# Altium schematic sheet P1.SchDoc
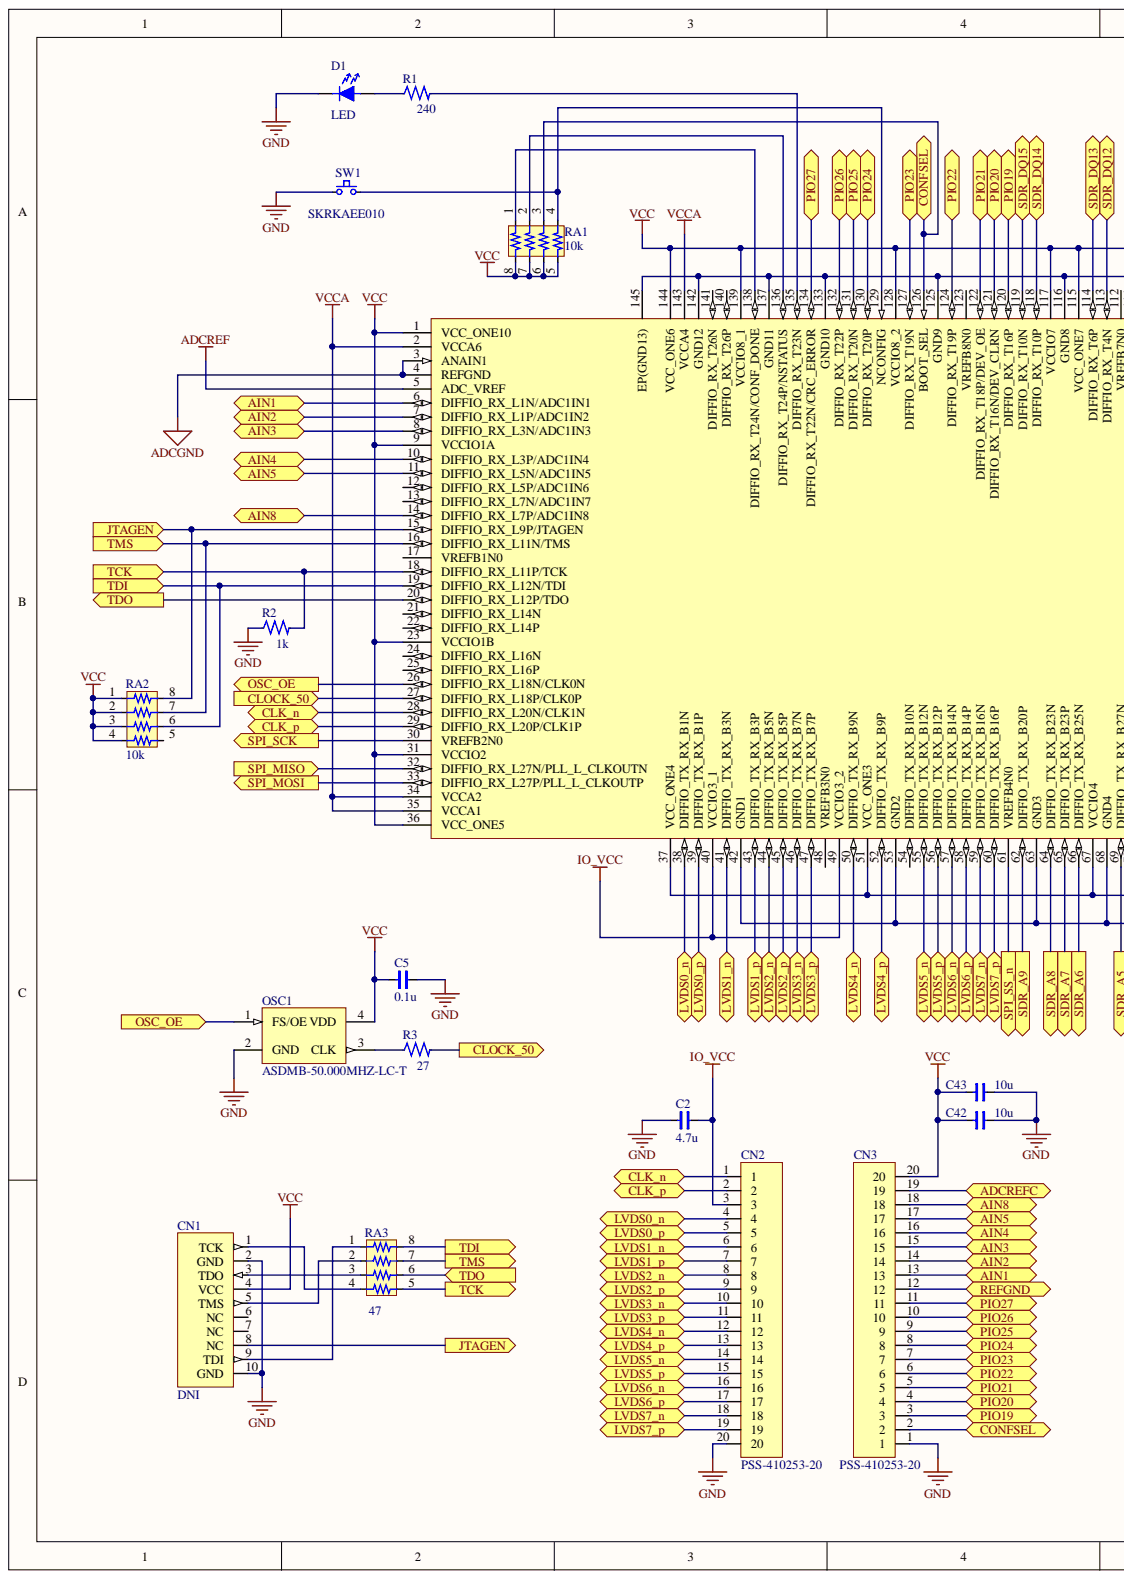
[diagram p0: P1.SchDoc, left]
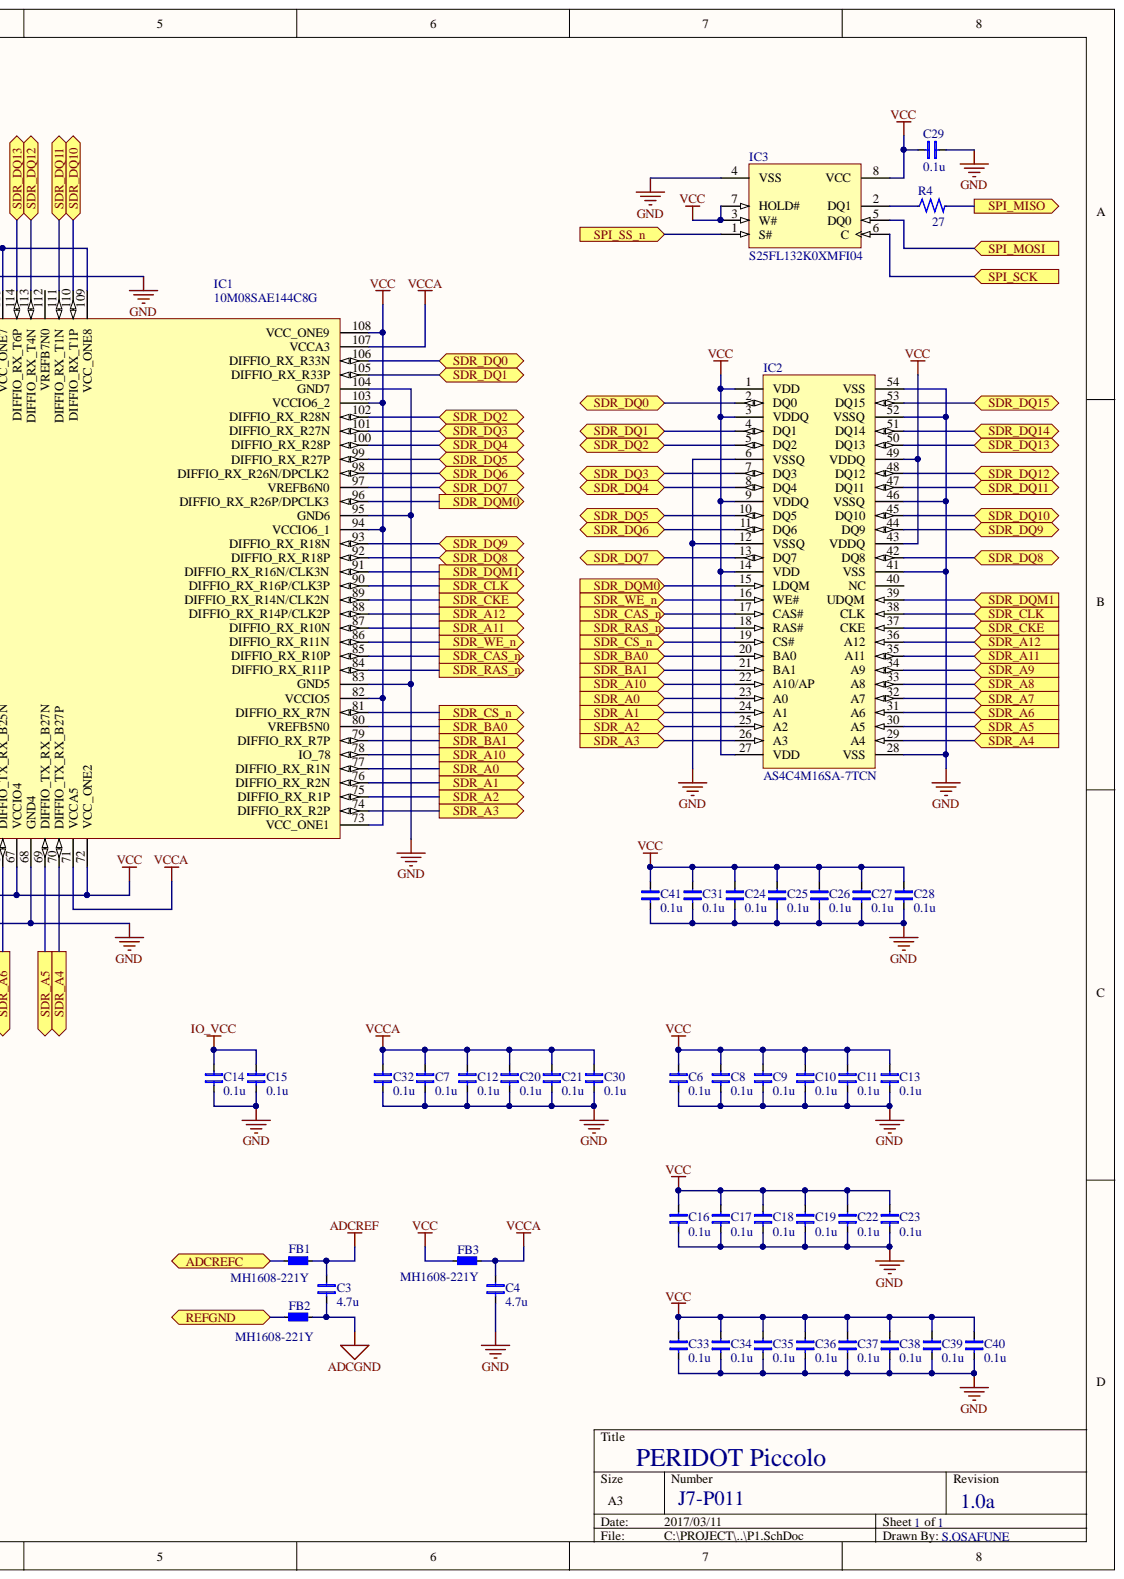
[diagram p1: P1.SchDoc, right]

## Parts
C2  4.7u  [C1608]
C3  4.7u  [C1608]
C4  4.7u  [C1608]
C5  0.1u  [C1608]
C6  0.1u  [C1608]
C7  0.1u  [C1608]
C8  0.1u  [C1608]
C9  0.1u  [C1608]
C10  0.1u  [C1608]
C11  0.1u  [C1608]
C12  0.1u  [C1608]
C13  0.1u  [C1608]
C14  0.1u  [C1608]
C15  0.1u  [C1608]
C16  0.1u  [C1608]
C17  0.1u  [C1608]
C18  0.1u  [C1608]
C19  0.1u  [C1608]
C20  0.1u  [C1608]
C21  0.1u  [C1608]
C22  0.1u  [C1608]
C23  0.1u  [C1608]
C24  0.1u  [C1608]
C25  0.1u  [C1608]
C26  0.1u  [C1608]
C27  0.1u  [C1608]
C28  0.1u  [C1608]
C29  0.1u  [C1608]
C30  0.1u  [C1608]
C31  0.1u  [C1608]
C32  0.1u  [C1608]
C33  0.1u  [C1608]
C34  0.1u  [C1608]
C35  0.1u  [C1608]
C36  0.1u  [C1608]
C37  0.1u  [C1608]
C38  0.1u  [C1608]
C39  0.1u  [C1608]
C40  0.1u  [C1608]
C41  0.1u  [C1608]
C42  10u  [C2012]
C43  10u  [C2012]
CN1  DNI  [PSS-420156-05]
CN2  PSS-410253-20  [PSS-410253-20]
CN3  PSS-410253-20  [PSS-410253-20]
D1  LED  [LED1608]
FB1  MH1608-221Y  [L1608]
FB2  MH1608-221Y  [L1608]
FB3  MH1608-221Y  [L1608]
IC1  10M08SAE144C8G  [10M08SAE144C8GES]
IC2  AS4C4M16SA-7TCN  [AS4C16M16S]
IC3  S25FL132K0XMFI04  [M25P16-VMN6P]
OSC1  ASDMB-50.000MHZ-LC-T  [SI50xC]
R1  240  [R1608]
R2  1k  [R1608]
R3  27  [R1608]
R4  27  [R1608]
RA1  10k  [RA1608X4]
RA2  10k  [RA1608X4]
RA3  47  [RA1608X4]
SW1  SKRKAEE010  [SKRKAEE010]

## Ports
ADCREFC, AIN1, AIN2, AIN3, AIN4, AIN5, AIN8, CLK_N, CLK_P, CLOCK_50, CONFSEL, JTAGEN, LVDS0_N, LVDS0_P, LVDS1_N, LVDS1_P, LVDS2_N, LVDS2_P, LVDS3_N, LVDS3_P, LVDS4_N, LVDS4_P, LVDS5_N, LVDS5_P, LVDS6_N, LVDS6_P, LVDS7_N, LVDS7_P, OSC_OE, PIO19, PIO20, PIO21, PIO22, PIO23, PIO24, PIO25, PIO26, PIO27, REFGND, SDR_A0, SDR_A1, SDR_A2, SDR_A3, SDR_A4, SDR_A5, SDR_A6, SDR_A7, SDR_A8, SDR_A9, SDR_A10, SDR_A11, SDR_A12, SDR_BA0, SDR_BA1, SDR_CAS_N, SDR_CKE, SDR_CLK, SDR_CS_N, SDR_DQ0, SDR_DQ1, SDR_DQ2, SDR_DQ3, SDR_DQ4, SDR_DQ5, SDR_DQ6, SDR_DQ7, SDR_DQ8, SDR_DQ9, SDR_DQ10, SDR_DQ11, SDR_DQ12, SDR_DQ13, SDR_DQ14, SDR_DQ15, SDR_DQM0, SDR_DQM1, SDR_RAS_N, SDR_WE_N, SPI_MISO, SPI_MOSI, SPI_SCK, SPI_SS_N, TCK, TDI, TDO, TMS

## Nets
ADCGND: C3.1 FB2.2 IC1.3(ANAIN1) IC1.4(REFGND)
ADCREF: C3.2 FB1.2 IC1.5(ADC_VREF)
CLK_N: CN2.1 IC1.28(DIFFIO_RX_L20N/CLK1N) ; port CLK_N
CLK_P: CN2.2 IC1.29(DIFFIO_RX_L20P/CLK1P) ; port CLK_P
GND: C2.1 C4.1 C5.2 C6.1 C7.1 C8.1 C9.1 C10.1 C11.1 C12.1 C13.1 C14.1 C15.1 C16.1 C17.1 C18.1 C19.1 C20.1 C21.1 C22.1 C23.1 C24.1 C25.1 C26.1 C27.1 C28.1 C29.2 C30.1 C31.1 C32.1 C33.1 C34.1 C35.1 C36.1 C37.1 C38.1 C39.1 C40.1 C41.1 C42.2 C43.2 CN1.2(GND) CN1.10(GND) CN2.20 CN3.1 D1.K IC1.42(GND1) IC1.53(GND2) IC1.63(GND3) IC1.68(GND4) IC1.83(GND5) IC1.95(GND6) IC1.104(GND7) IC1.116(GND8) IC1.125(GND9) IC1.133(GND10) IC1.137(GND11) IC1.142(GND12) IC1.145(EP(GND13)) IC2.6(VSSQ) IC2.12(VSSQ) IC2.28(VSS) IC2.41(VSS) IC2.46(VSSQ) IC2.52(VSSQ) IC2.54(VSS) IC3.4(VSS) OSC1.2(GND) R2.1 SW1.1
IO_VCC: C2.2 C14.2 C15.2 CN2.3 IC1.40(VCCIO3_1) IC1.49(VCCIO3_2)
LVDS0_N: CN2.4 IC1.38(DIFFIO_TX_RX_B1N) ; port LVDS0_N
LVDS0_P: CN2.5 IC1.39(DIFFIO_TX_RX_B1P) ; port LVDS0_P
LVDS1_N: CN2.6 IC1.41(DIFFIO_TX_RX_B3N) ; port LVDS1_N
LVDS1_P: CN2.7 IC1.43(DIFFIO_TX_RX_B3P) ; port LVDS1_P
LVDS2_N: CN2.8 IC1.44(DIFFIO_TX_RX_B5N) ; port LVDS2_N
LVDS2_P: CN2.9 IC1.45(DIFFIO_TX_RX_B5P) ; port LVDS2_P
LVDS3_N: CN2.10 IC1.46(DIFFIO_TX_RX_B7N) ; port LVDS3_N
LVDS3_P: CN2.11 IC1.47(DIFFIO_TX_RX_B7P) ; port LVDS3_P
LVDS4_N: CN2.12 IC1.50(DIFFIO_TX_RX_B9N) ; port LVDS4_N
LVDS4_P: CN2.13 IC1.52(DIFFIO_TX_RX_B9P) ; port LVDS4_P
LVDS5_N: CN2.14 IC1.55(DIFFIO_TX_RX_B12N) ; port LVDS5_N
LVDS5_P: CN2.15 IC1.56(DIFFIO_TX_RX_B12P) ; port LVDS5_P
LVDS6_N: CN2.16 IC1.57(DIFFIO_TX_RX_B14N) ; port LVDS6_N
LVDS6_P: CN2.17 IC1.58(DIFFIO_TX_RX_B14P) ; port LVDS6_P
LVDS7_N: CN2.18 IC1.59(DIFFIO_TX_RX_B16N) ; port LVDS7_N
LVDS7_P: CN2.19 IC1.60(DIFFIO_TX_RX_B16P) ; port LVDS7_P
SDR_CAS_N: IC1.85(DIFFIO_RX_R10P) IC2.17(CAS#) ; port SDR_CAS_N
SDR_CS_N: IC1.81(DIFFIO_RX_R7N) IC2.19(CS#) ; port SDR_CS_N
SDR_RAS_N: IC1.84(DIFFIO_RX_R11P) IC2.18(RAS#) ; port SDR_RAS_N
SDR_WE_N: IC1.86(DIFFIO_RX_R11N) IC2.16(WE#) ; port SDR_WE_N
SPI_SS_N: IC1.61(VREFB4N0) IC3.1(S#) ; port SPI_SS_N
VCC: C5.1 C6.2 C8.2 C9.2 C10.2 C11.2 C13.2 C16.2 C17.2 C18.2 C19.2 C22.2 C23.2 C24.2 C25.2 C26.2 C27.2 C28.2 C29.1 C31.2 C33.2 C34.2 C35.2 C36.2 C37.2 C38.2 C39.2 C40.2 C41.2 C42.1 C43.1 CN1.4(VCC) CN3.20 FB3.1 IC1.1(VCC_ONE10) IC1.9(VCCIO1A) IC1.23(VCCIO1B) IC1.31(VCCIO2) IC1.36(VCC_ONE5) IC1.37(VCC_ONE4) IC1.51(VCC_ONE3) IC1.67(VCCIO4) IC1.72(VCC_ONE2) IC1.73(VCC_ONE1) IC1.82(VCCIO5) IC1.94(VCCIO6_1) IC1.103(VCCIO6_2) IC1.108(VCC_ONE9) IC1.109(VCC_ONE8) IC1.115(VCC_ONE7) IC1.117(VCCIO7) IC1.128(VCCIO8_2) IC1.139(VCCIO8_1) IC1.144(VCC_ONE6) IC2.1(VDD) IC2.3(VDDQ) IC2.9(VDDQ) IC2.14(VDD) IC2.27(VDD) IC2.43(VDDQ) IC2.49(VDDQ) IC3.3(W#) IC3.7(HOLD#) IC3.8(VCC) OSC1.4(VDD) RA1.5 RA1.6 RA1.7 RA1.8 RA2.1 RA2.2 RA2.3 RA2.4
VCCA: C4.2 C7.2 C12.2 C20.2 C21.2 C30.2 C32.2 FB3.2 IC1.2(VCCA6) IC1.34(VCCA2) IC1.35(VCCA1) IC1.71(VCCA5) IC1.107(VCCA3) IC1.143(VCCA4)
ADCREFC: CN3.19 FB1.1 ; port ADCREFC
AIN1: CN3.13 IC1.6(DIFFIO_RX_L1N/ADC1IN1) ; port AIN1
AIN2: CN3.14 IC1.7(DIFFIO_RX_L1P/ADC1IN2) ; port AIN2
AIN3: CN3.15 IC1.8(DIFFIO_RX_L3N/ADC1IN3) ; port AIN3
AIN4: CN3.16 IC1.10(DIFFIO_RX_L3P/ADC1IN4) ; port AIN4
AIN5: CN3.17 IC1.11(DIFFIO_RX_L5N/ADC1IN5) ; port AIN5
AIN8: CN3.18 IC1.14(DIFFIO_RX_L7P/ADC1IN8) ; port AIN8
CLOCK_50: IC1.27(DIFFIO_RX_L18P/CLK0P) R3.2 ; port CLOCK_50
CONFSEL: CN3.2 IC1.126(BOOT_SEL) RA1.3 ; port CONFSEL
JTAGEN: CN1.8(NC) IC1.15(DIFFIO_RX_L9P/JTAGEN) RA2.8 ; port JTAGEN
OSC_OE: IC1.26(DIFFIO_RX_L18N/CLK0N) OSC1.1(FS/OE) ; port OSC_OE
PIO19: CN3.3 IC1.120(DIFFIO_RX_T16P) ; port PIO19
PIO20: CN3.4 IC1.121(DIFFIO_RX_T16N/DEV_CLRN) ; port PIO20
PIO21: CN3.5 IC1.122(DIFFIO_RX_T18P/DEV_OE) ; port PIO21
PIO22: CN3.6 IC1.124(DIFFIO_RX_T19P) ; port PIO22
PIO23: CN3.7 IC1.127(DIFFIO_RX_T19N) ; port PIO23
PIO24: CN3.8 IC1.130(DIFFIO_RX_T20P) ; port PIO24
PIO25: CN3.9 IC1.131(DIFFIO_RX_T20N) ; port PIO25
PIO26: CN3.10 IC1.132(DIFFIO_RX_T22P) ; port PIO26
PIO27: CN3.11 IC1.134(DIFFIO_RX_T22N/CRC_ERROR) ; port PIO27
REFGND: CN3.12 FB2.1 ; port REFGND
SDR_A0: IC1.77(DIFFIO_RX_R1N) IC2.23(A0) ; port SDR_A0
SDR_A1: IC1.76(DIFFIO_RX_R2N) IC2.24(A1) ; port SDR_A1
SDR_A2: IC1.75(DIFFIO_RX_R1P) IC2.25(A2) ; port SDR_A2
SDR_A3: IC1.74(DIFFIO_RX_R2P) IC2.26(A3) ; port SDR_A3
SDR_A4: IC1.70(DIFFIO_TX_RX_B27P) IC2.29(A4) ; port SDR_A4
SDR_A5: IC1.69(DIFFIO_TX_RX_B27N) IC2.30(A5) ; port SDR_A5
SDR_A6: IC1.66(DIFFIO_TX_RX_B25N) IC2.31(A6) ; port SDR_A6
SDR_A7: IC1.65(DIFFIO_TX_RX_B23P) IC2.32(A7) ; port SDR_A7
SDR_A8: IC1.64(DIFFIO_TX_RX_B23N) IC2.33(A8) ; port SDR_A8
SDR_A9: IC1.62(DIFFIO_TX_RX_B20P) IC2.34(A9) ; port SDR_A9
SDR_A10: IC1.78(IO_78) IC2.22(A10/AP) ; port SDR_A10
SDR_A11: IC1.87(DIFFIO_RX_R10N) IC2.35(A11) ; port SDR_A11
SDR_A12: IC1.88(DIFFIO_RX_R14P/CLK2P) IC2.36(A12) ; port SDR_A12
SDR_BA0: IC1.80(VREFB5N0) IC2.20(BA0) ; port SDR_BA0
SDR_BA1: IC1.79(DIFFIO_RX_R7P) IC2.21(BA1) ; port SDR_BA1
SDR_CKE: IC1.89(DIFFIO_RX_R14N/CLK2N) IC2.37(CKE) ; port SDR_CKE
SDR_CLK: IC1.90(DIFFIO_RX_R16P/CLK3P) IC2.38(CLK) ; port SDR_CLK
SDR_DQ0: IC1.106(DIFFIO_RX_R33N) IC2.2(DQ0) ; port SDR_DQ0
SDR_DQ1: IC1.105(DIFFIO_RX_R33P) IC2.4(DQ1) ; port SDR_DQ1
SDR_DQ2: IC1.102(DIFFIO_RX_R28N) IC2.5(DQ2) ; port SDR_DQ2
SDR_DQ3: IC1.101(DIFFIO_RX_R27N) IC2.7(DQ3) ; port SDR_DQ3
SDR_DQ4: IC1.100(DIFFIO_RX_R28P) IC2.8(DQ4) ; port SDR_DQ4
SDR_DQ5: IC1.99(DIFFIO_RX_R27P) IC2.10(DQ5) ; port SDR_DQ5
SDR_DQ6: IC1.98(DIFFIO_RX_R26N/DPCLK2) IC2.11(DQ6) ; port SDR_DQ6
SDR_DQ7: IC1.97(VREFB6N0) IC2.13(DQ7) ; port SDR_DQ7
SDR_DQ8: IC1.92(DIFFIO_RX_R18P) IC2.42(DQ8) ; port SDR_DQ8
SDR_DQ9: IC1.93(DIFFIO_RX_R18N) IC2.44(DQ9) ; port SDR_DQ9
SDR_DQ10: IC1.110(DIFFIO_RX_T1P) IC2.45(DQ10) ; port SDR_DQ10
SDR_DQ11: IC1.111(DIFFIO_RX_T1N) IC2.47(DQ11) ; port SDR_DQ11
SDR_DQ12: IC1.113(DIFFIO_RX_T4N) IC2.48(DQ12) ; port SDR_DQ12
SDR_DQ13: IC1.114(DIFFIO_RX_T6P) IC2.50(DQ13) ; port SDR_DQ13
SDR_DQ14: IC1.118(DIFFIO_RX_T10P) IC2.51(DQ14) ; port SDR_DQ14
SDR_DQ15: IC1.119(DIFFIO_RX_T10N) IC2.53(DQ15) ; port SDR_DQ15
SDR_DQM0: IC1.96(DIFFIO_RX_R26P/DPCLK3) IC2.15(LDQM) ; port SDR_DQM0
SDR_DQM1: IC1.91(DIFFIO_RX_R16N/CLK3N) IC2.39(UDQM) ; port SDR_DQM1
SPI_MISO: IC1.32(DIFFIO_RX_L27N/PLL_L_CLKOUTN) R4.2 ; port SPI_MISO
SPI_MOSI: IC1.33(DIFFIO_RX_L27P/PLL_L_CLKOUTP) IC3.5(DQ0) ; port SPI_MOSI
SPI_SCK: IC1.30(VREFB2N0) IC3.6(C) ; port SPI_SCK
TCK: IC1.18(DIFFIO_RX_L11P/TCK) R2.2 RA3.5 ; port TCK
TDI: IC1.19(DIFFIO_RX_L12N/TDI) RA2.6 RA3.8 ; port TDI
TDO: IC1.20(DIFFIO_RX_L12P/TDO) RA3.6 ; port TDO
TMS: IC1.16(DIFFIO_RX_L11N/TMS) RA2.7 RA3.7 ; port TMS
NetCN1_1: CN1.1(TCK) RA3.4
NetCN1_3: CN1.3(TDO) RA3.3
NetCN1_5: CN1.5(TMS) RA3.2
NetCN1_9: CN1.9(TDI) RA3.1
NetD1_A: D1.A R1.1
NetIC1_129: IC1.129(NCONFIG) RA1.4 SW1.2
NetIC1_135: IC1.135(DIFFIO_RX_T23N) R1.2
NetIC1_136: IC1.136(DIFFIO_RX_T24P/NSTATUS) RA1.2
NetIC1_138: IC1.138(DIFFIO_RX_T24N/CONF_DONE) RA1.1
NetIC3_2: IC3.2(DQ1) R4.1
NetOSC1_3: OSC1.3(CLK) R3.1

Not connected: CN1 6(NC) 7(NC); IC1 12(DIFFIO_RX_L5P/ADC1IN6) 13(DIFFIO_RX_L7N/ADC1IN7) 17(VREFB1N0) 21(DIFFIO_RX_L14N) 22(DIFFIO_RX_L14P) 24(DIFFIO_RX_L16N) 25(DIFFIO_RX_L16P) 48(VREFB3N0) 54(DIFFIO_TX_RX_B10N) 112(VREFB7N0) 123(VREFB8N0) 140(DIFFIO_RX_T26P) 141(DIFFIO_RX_T26N); IC2 40(NC); RA2 5

## Library data of the parts
C2-C43 = C: Capacitor (non-polar) 2pin; Component Kind: Standard; Description 1: Capacitor (non-polar); FirstPublished: 2012/10/14; Library Name: RCL.SchLib; Supplier 1: Digi-Key; Supplier 2: MOUSER; Publisher: yuna_digick
CN1 = USB-Blaster CONN: USB-Blaster CONN MIL10P; Manufacturer: OMRON; Manufacturer Part Number: XG4C-4031; Description 2: PLUG 10POS 3A 300V STRT DIP; Component Kind: Standard; Description 1: CONN; FirstPublished: 2014/04/18; Library Name: Modules.SchLib; Supplier 1: Digi-Key; Supplier 2: MOUSER; Supplier Part Number 1: OR896-ND; Supplier Part Number 2: 653-XG4C-1031; Publisher: koiseya
CN2 CN3 = PSM-410233-20: Connector 2.54mm 1X20P h=2.3 SMD; Manufacturer: HIROSUGI; Description 1: CONN; Description 2: PLUG 20POS 3A 500V STRT SMD; Component Kind: Standard; FirstPublished: 2014/11/12; Library Name: Modules.SchLib; Manufacturer Part Number: PSM-410233-20; Supplier 1: Digi-Key; Supplier 2: MOUSER; Publisher: koiseya
FB1-FB3 = MH1608-221Y: Ferrite Bead 220ohm@100MHz 1608; Manufacturer: Bourns Inc.; Description 2: FERRITE 220 OHM 2A 1608; Component Kind: Standard; Description 1: BEAD; FirstPublished: 2014/11/13; Library Name: RCL.SchLib; Manufacturer Part Number: MH1608-221Y; Supplier 1: Digi-Key; Supplier 2: MOUSER; Supplier Part Number 1: MH1608-221YCT-ND; Supplier Part Number 2: 652-MH1608-221Y; Publisher: koiseya
IC1 = 10M08SAE144C8GES: FPGA MAX10 101I/O 3.3V EQFP144; Manufacturer: Altera Corporation; Description 1: IC; Description 2: FPGA/CPLD NV 101 I/O 144EQFP; Component Kind: Standard; FirstPublished: 2014/11/7; Library Name: Modules.SchLib; Manufacturer Part Number: 10M08SAE144C8GES; Supplier 1: Digi-Key; Supplier 2: MOUSER; Supplier Part Number 1: 544-3037-ND; Supplier Part Number 2: 989-10M08SAE144C8GES; Publisher: koiseya
IC2 = AS4C16M16S: SDRAM 3.3V 256M (16Mx16) 7ns TSOP54; Manufacturer: Alliance Memory, Inc.; Manufacturer Part Number: AS4C16M16S-7TCN; Description 1: IC; Description 2: SDRAM 256MBIT 143MHZ 54TSOP; Component Kind: Standard; FirstPublished: 2014/11/11; Library Name: Modules.SchLib; PackageReference: TSOP 54P; Supplier 1: Digi-Key; Supplier 2: MOUSER; Supplier Part Number 1: 1450-1003-ND; Supplier Part Number 2: 913-AS4C16M16S-7TCN; Publisher: koiseya
IC3 = M25P16-VMN6P: 16 Mb (x1) Serial NOR Flash Memory, 75 MHz, 2.7 to 3.6 V, 8-pin SO8 Narrow (MN), Tube; Manufacturer: Micron; Part Family: M25P; Density: 16Mb; Speed: 75 MHz; Voltage: 2.7V-3.6V; Applications: Embedded; Component Kind: Standard; ComponentLink1Description: Manufacturer URL; ComponentLink1URL: http://www.micron.com/; ComponentLink2Description: Datasheet; ComponentLink2URL: http://www.micron.com/~/media/Documents/Products/Data%20Sheet/NOR%20Flash/Serial%20NOR/M25P/M25P16.pdf; ComponentLink3Description: Package Specification; ComponentLink3URL: http://www.micron.com/~/media/Documents/Products/Data%20Sheet/NOR%20Flash/Serial%20NOR/M25P/M25P05A.pdf; DatasheetVersion: Rev. Y; Library Name: Micron Memory Serial NOR Flash.IntLib; Mounting Technology: Surface Mount; Package: SO8 Narrow; PackageDescription: 8-Pin SO Narrow, 3.9 X 4.9 mm, Pitch 1.27 mm; PackageReference: MICT-MN-8; PackageVersion: Rev 11; PartNumber: M25P16-VMN6P; Part Status: Production; RoHS: Yes; Type: Data Storage; Width: x1
OSC1 = 503HAA-ACAF: OSC CMEMS QuadFreq 50ppm 1.8-3.3V 3225; Manufacturer: Silicon Laboratories Inc; Description 2: PROG 0.7NS 50PPM 2.5X3.2MM; Component Kind: Standard; Description 1: OSC; FirstPublished: 2014/11/13; Library Name: Modules.SchLib; Manufacturer Part Number: 503HAA-ACAF; Supplier 1: Digi-Key; Supplier 2: MOUSER; Supplier Part Number 1: 503HAA-ACAF-ND; Publisher: koiseya
R1-R4 = R: Resisiter 2pin; Description 1: Rsisiter; Component Kind: Standard; FirstPublished: 2012/10/14; Library Name: RCL.SchLib; Supplier 1: Digi-Key; Supplier 2: MOUSER; Publisher: yuna_digick
RA1-RA3 = RA4: Rsisiter Array 4pair; Description 1: Resister Network 4pair; Component Kind: Standard; FirstPublished: 2012/11/05; Library Name: RCL.SchLib; Supplier 1: Digi-Key; Supplier 2: MOUSER; Publisher: yuna_digick
SW1 = TVBP06-BN043CW-B: Switch Tact 12V 50mA White; Manufacturer: Zhejiang Jianfu Electronics; Description 1: TACT Switch; Description 2: 3.5x6.0x4.3mm White Stem; Component Kind: Standard; FirstPublished: 2014/11/13; Library Name: Modules.SchLib; Manufacturer Part Number: TVBP06-BN043CW-B; Supplier 1: Digi-Key; Supplier 2: MOUSER; Publisher: koiseya
D1 = LED: Component Kind: Standard; Description: LED; Description 1: LED; FirstPublished: 2013/07/10; Library Name: RCL.SchLib; Supplier 1: Digi-Key; Supplier 2: MOUSER; Publisher: koiseya
